# Altium schematic sheet ZYNQ_PWR_BANK.SchDoc(ZYNQ_PWR_BANK)
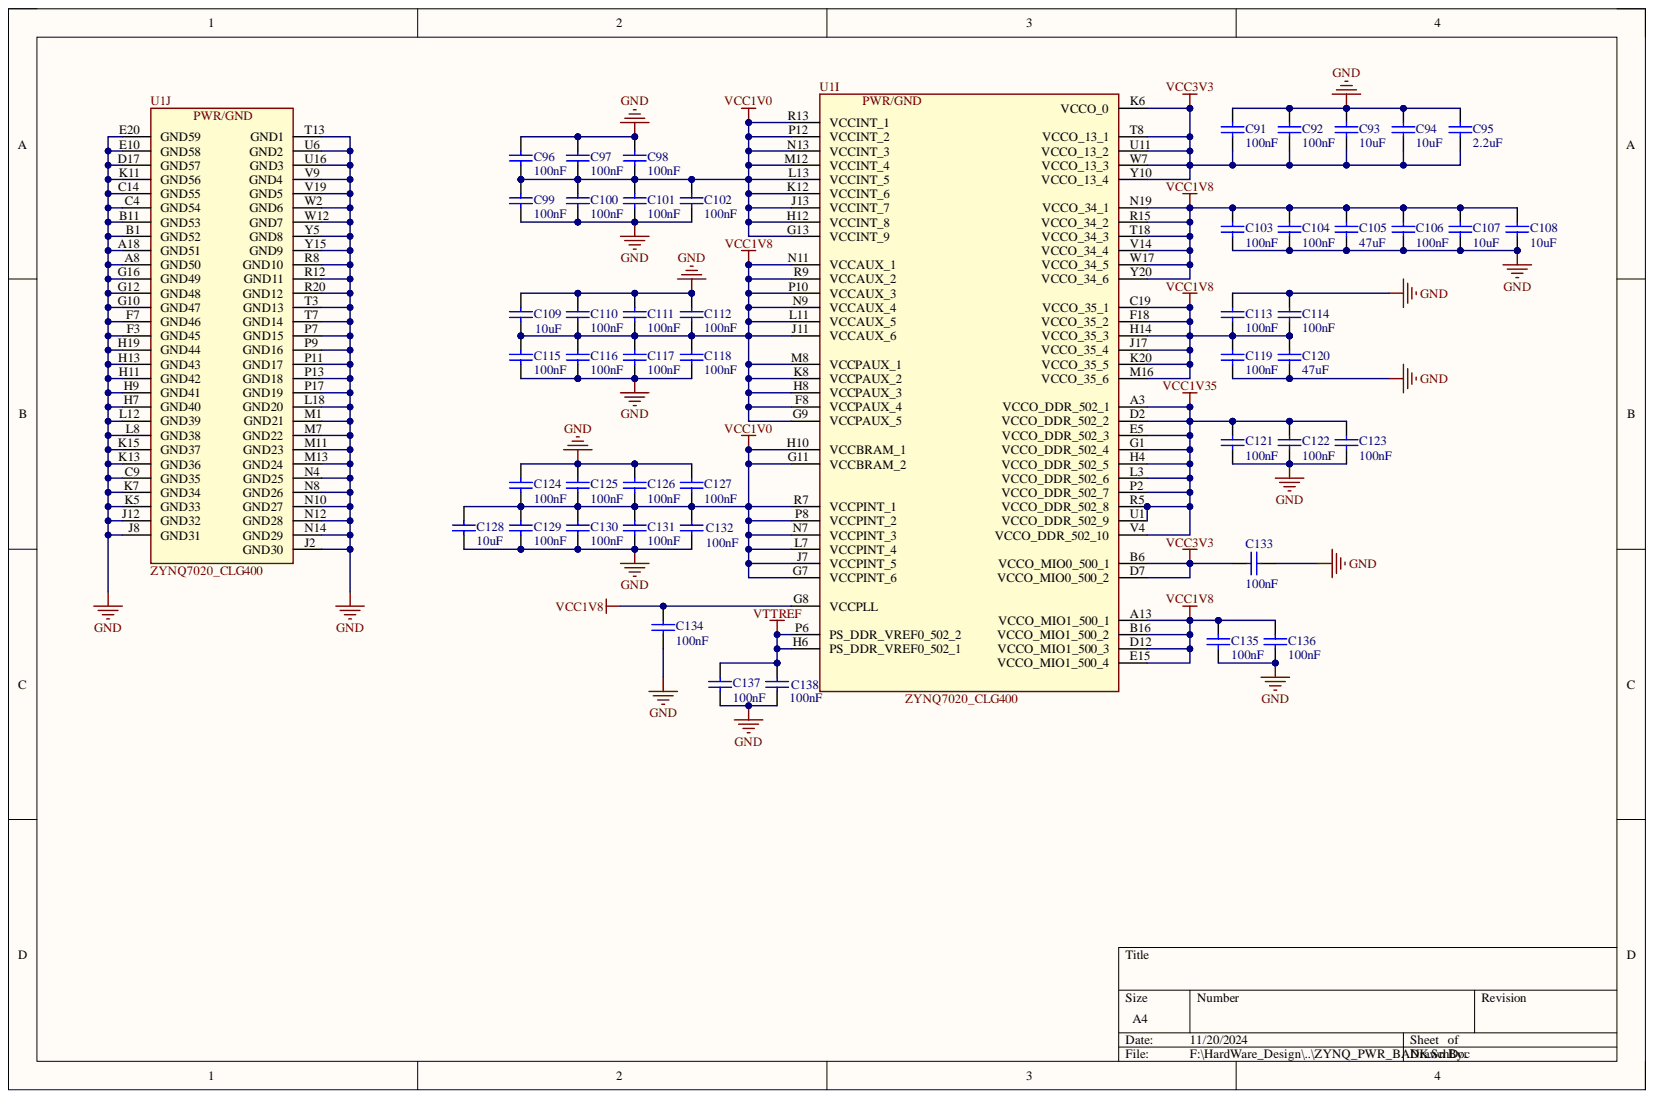
[diagram p0: ZYNQ_PWR_BANK.SchDoc]

## Parts
C91  100nF  [C 0402_L]
C92  100nF  [C 0402_L]
C93  10uF  [C 0603_L]
C94  10uF  [C 0603_L]
C95  10uF  value 2.2uF  [C 0402_L]
C96  100nF  [C 0402_L]
C97  100nF  [C 0402_L]
C98  100nF  [C 0402_L]
C99  100nF  [C 0402_L]
C100  100nF  [C 0402_L]
C101  100nF  [C 0402_L]
C102  100nF  [C 0402_L]
C103  100nF  [C 0402_L]
C104  100nF  [C 0402_L]
C105  47uF  [C 0805_L]
C106  100nF  [C 0402_L]
C107  10uF  [C 0603_L]
C108  10uF  [C 0603_L]
C109  10uF  [C 0603_L]
C110  100nF  [C 0402_L]
C111  100nF  [C 0402_L]
C112  100nF  [C 0402_L]
C113  100nF  [C 0402_L]
C114  100nF  [C 0402_L]
C115  100nF  [C 0402_L]
C116  100nF  [C 0402_L]
C117  100nF  [C 0402_L]
C118  100nF  [C 0402_L]
C119  100nF  [C 0402_L]
C120  47uF  [C 0805_L]
C121  100nF  [C 0402_L]
C122  100nF  [C 0402_L]
C123  100nF  [C 0402_L]
C124  100nF  [C 0402_L]
C125  100nF  [C 0402_L]
C126  100nF  [C 0402_L]
C127  100nF  [C 0402_L]
C128  10uF  [C 0603_L]
C129  100nF  [C 0402_L]
C130  100nF  [C 0402_L]
C131  100nF  [C 0402_L]
C132  100nF  [C 0402_L]
C133  100nF  [C 0402_L]
C134  100nF  [C 0402_L]
C135  100nF  [C 0402_L]
C136  100nF  [C 0402_L]
C137  100nF  [C 0402_L]
C138  100nF  [C 0402_L]
U1  ZYNQ7020_CLG400  [BGA400C80P20X20_1700X1700X160]  (parts I,J)

## Nets
GND: C91.1 C92.1 C93.1 C94.1 C95.1 C96.1 C97.1 C98.1 C99.2 C100.2 C101.2 C102.2 C103.2 C104.2 C105.2 C106.2 C107.2 C108.2 C109.1 C110.1 C111.1 C112.1 C113.1 C114.1 C115.2 C116.2 C117.2 C118.2 C119.2 C120.2 C121.2 C122.2 C123.2 C124.1 C125.1 C126.1 C127.1 C128.2 C129.2 C130.2 C131.2 C132.2 C133.1 C134.1 C135.2 C136.2 C137.2 C138.2 U1.A8(GND50) U1.A18(GND51) U1.B1(GND52) U1.B11(GND53) U1.C4(GND54) U1.C9(GND35) U1.C14(GND55) U1.D17(GND57) U1.E10(GND58) U1.E20(GND59) U1.F3(GND45) U1.F7(GND46) U1.G10(GND47) U1.G12(GND48) U1.G16(GND49) U1.H7(GND40) U1.H9(GND41) U1.H11(GND42) U1.H13(GND43) U1.H19(GND44) U1.J2(GND30) U1.J8(GND31) U1.J12(GND32) U1.K5(GND33) U1.K7(GND34) U1.K11(GND56) U1.K13(GND36) U1.K15(GND37) U1.L8(GND38) U1.L12(GND39) U1.L18(GND20) U1.M1(GND21) U1.M7(GND22) U1.M11(GND23) U1.M13(GND24) U1.N4(GND25) U1.N8(GND26) U1.N10(GND27) U1.N12(GND28) U1.N14(GND29) U1.P7(GND15) U1.P9(GND16) U1.P11(GND17) U1.P13(GND18) U1.P17(GND19) U1.R8(GND10) U1.R12(GND11) U1.R20(GND12) U1.T3(GND13) U1.T7(GND14) U1.T13(GND1) U1.U6(GND2) U1.U16(GND3) U1.V9(GND4) U1.V19(GND5) U1.W2(GND6) U1.W12(GND7) U1.Y5(GND8) U1.Y15(GND9)
VCC1V0: C96.2 C97.2 C98.2 C99.1 C100.1 C101.1 C102.1 C124.2 C125.2 C126.2 C127.2 C128.1 C129.1 C130.1 C131.1 C132.1 U1.G7(VCCPINT_6) U1.G11(VCCBRAM_2) U1.G13(VCCINT_9) U1.H10(VCCBRAM_1) U1.H12(VCCINT_8) U1.J7(VCCPINT_5) U1.J13(VCCINT_7) U1.K12(VCCINT_6) U1.L7(VCCPINT_4) U1.L13(VCCINT_5) U1.M12(VCCINT_4) U1.N7(VCCPINT_3) U1.N13(VCCINT_3) U1.P8(VCCPINT_2) U1.P12(VCCINT_2) U1.R7(VCCPINT_1) U1.R13(VCCINT_1)
VCC1V8: C103.1 C104.1 C105.1 C106.1 C107.1 C108.1 C109.2 C110.2 C111.2 C112.2 C113.2 C114.2 C115.1 C116.1 C117.1 C118.1 C119.1 C120.1 C134.2 C135.1 C136.1 U1.A13(VCCO_MIO1_500_1) U1.B16(VCCO_MIO1_500_2) U1.C19(VCCO_35_1) U1.D12(VCCO_MIO1_500_3) U1.E15(VCCO_MIO1_500_4) U1.F8(VCCPAUX_4) U1.F18(VCCO_35_2) U1.G8(VCCPLL) U1.G9(VCCPAUX_5) U1.H8(VCCPAUX_3) U1.H14(VCCO_35_3) U1.J11(VCCAUX_6) U1.J17(VCCO_35_4) U1.K8(VCCPAUX_2) U1.K20(VCCO_35_5) U1.L11(VCCAUX_5) U1.M8(VCCPAUX_1) U1.M16(VCCO_35_6) U1.N9(VCCAUX_4) U1.N11(VCCAUX_1) U1.N19(VCCO_34_1) U1.P10(VCCAUX_3) U1.R9(VCCAUX_2) U1.R15(VCCO_34_2) U1.T18(VCCO_34_3) U1.V14(VCCO_34_4) U1.W17(VCCO_34_5) U1.Y20(VCCO_34_6)
VCC1V35: C121.1 C122.1 C123.1 U1.A3(VCCO_DDR_502_1) U1.D2(VCCO_DDR_502_2) U1.E5(VCCO_DDR_502_3) U1.G1(VCCO_DDR_502_4) U1.H4(VCCO_DDR_502_5) U1.L3(VCCO_DDR_502_6) U1.P2(VCCO_DDR_502_7) U1.R5(VCCO_DDR_502_8) U1.U1(VCCO_DDR_502_9) U1.V4(VCCO_DDR_502_10)
VCC3V3: C91.2 C92.2 C93.2 C94.2 C95.2 C133.2 U1.B6(VCCO_MIO0_500_1) U1.D7(VCCO_MIO0_500_2) U1.K6(VCCO_0) U1.T8(VCCO_13_1) U1.U11(VCCO_13_2) U1.W7(VCCO_13_3) U1.Y10(VCCO_13_4)
VTTREF: C137.1 C138.1 U1.H6(PS_DDR_VREF0_502_1) U1.P6(PS_DDR_VREF0_502_2)

## Library data of the parts
C91-C138 = Cap1: Component Kind: Standard; Library Name: 零散元件库.SCHLIB
  C91 C92 C96-C104 C106 C110-C119 C121-C127 C129-C138: value: 100nF
  C93 C94 C107-C109 C128: value: 10uF
  C105 C120: value: 47uF
U1 = XC7Z020: Zynq 7000 FPGA 20 Die, in a CSG 484 Package; Manufacturer: Xilinx; Component Kind: Standard; Library Name: Miscellaneous Devices.IntLib
